# Altium schematic sheet Wifi, Cellular, MD and FRAM.SchDoc
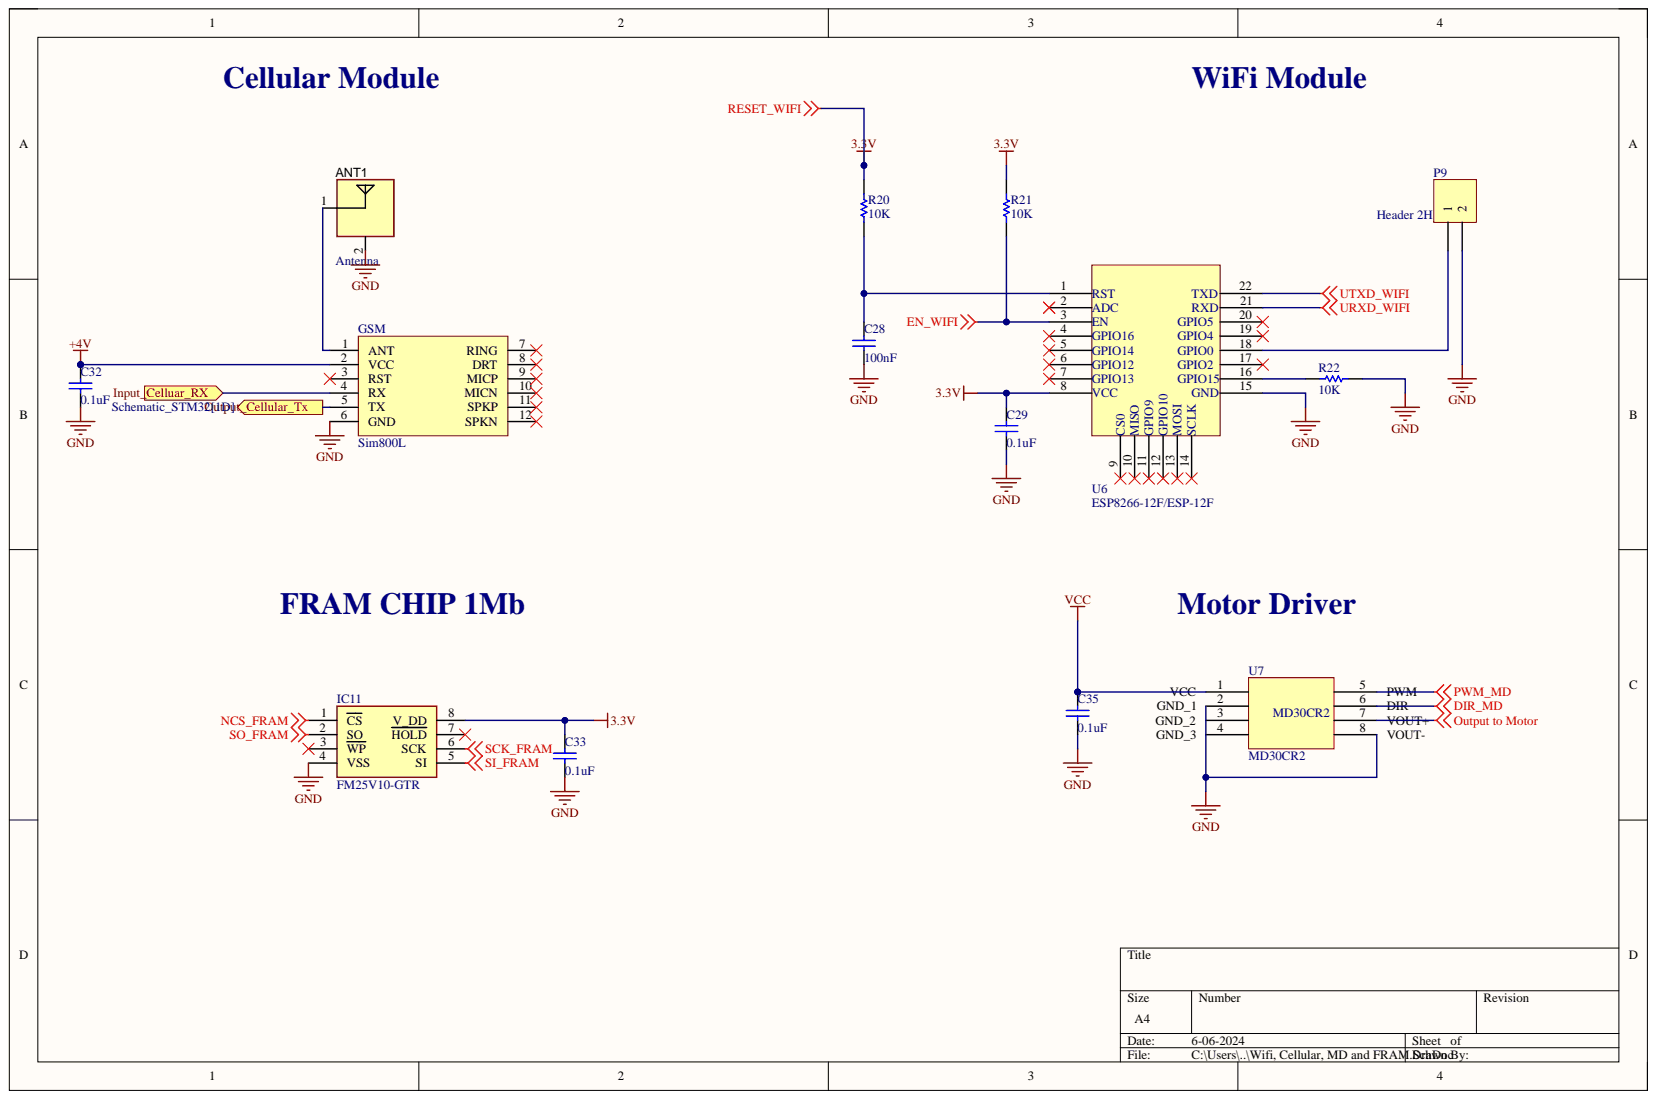
[diagram p0: Wifi, Cellular, MD and FRAM.SchDoc]

## Parts
ANT1  value Antenna  [ANT_IFA_2P4G]  (no BOM)
C28  value 100nF  [RAD-0.3]  (hidden comment: Cap)
C29  value 0.1uF  [RAD-0.3]  (hidden comment: Cap)
C32  value 0.1uF  [RAD-0.3]  (hidden comment: Cap)
C33  value 0.1uF  [RAD-0.3]  (hidden comment: Cap)
C35  value 0.1uF  [RAD-0.3]  (hidden comment: Cap)
GSM  Sim800L  [Sim800L]
IC11  FM25V10-GTR  [SOIC127P602X173-8N]
P9  Header 2H  [HDR1X2H]
R20  value 10K  [AXIAL-0.3]  (hidden comment: Res1)
R21  value 10K  [AXIAL-0.3]  (hidden comment: Res1)
R22  value 10K  [AXIAL-0.3]  (hidden comment: Res1)
U6  ESP8266-12F/ESP-12F
U7  MD30CR2

## Ports
Input_Celluar_RX, Output_Cellular_Tx

## Nets
+4V: C32.2 GSM.2(VCC)
EN_WIFI: R21.2
GND: ANT1.2 C28.1 C29.1 C32.1 C33.1 C35.1 GSM.6(GND) IC11.4(VSS) P9.2 R22.2
NCS_FRAM: IC11.1(CS)
RESET_WIFI: C29.2 C33.2 IC11.8(V_DD) R20.1 R21.1
SCK_FRAM: IC11.6(SCK)
SI_FRAM: IC11.5(SI)
SO_FRAM: IC11.2(SO)
VCC: C35.2
Input_Celluar_RX: GSM.4(RX) ; port Input_Celluar_RX
Output_Cellular_Tx: GSM.5(TX) ; port Output_Cellular_Tx
NetANT1_1: ANT1.1 GSM.1(ANT)
NetC28_2: C28.2 R20.2
DIR_MD:
Output to Motor:
PWM_MD:
URXD_WIFI:
UTXD_WIFI:

Not connected: GSM 3(RST) 7(RING) 8(DRT) 9(MICP) 10(MICN) 11(SPKP) 12(SPKN); IC11 3(WP) 7(HOLD); P9 1; R22 1; U6 1(RST) 2(ADC) 3(EN) 4(GPIO16) 5(GPIO14) 6(GPIO12) 7(GPIO13) 8(VCC) 9(CS0) 10(MISO) 11(GPIO9) 12(GPIO10) 13(MOSI) 14(SCLK) 15(GND) 16(GPIO15) 17(GPIO2) 18(GPIO0) 19(GPIO4) 20(GPIO5) 21(RXD) 22(TXD); U7 1 2 3 4 5 6 7 8

## Library data of the parts
ANT1 = TESTPOINT_SMD: TEST POINT; Library Name: antenna.SchLib; MANF: MANF; MANF_P#: MANF_P#
C28 C29 C32 C33 C35 = Cap: Capacitor; Component Kind: Standard; LatestRevisionDate: 17-Jul-2002; LatestRevisionNote: Re-released for DXP Platform.; Library Name: Miscellaneous Devices.IntLib; PackageDescription: Radial Cap, Thru-Hole; 2 Leads; 0.3 in Pin Spacing; PackageReference: RAD-0.3; Published: 8-Jun-2000; Signal Integrity: Cap; Simulation: CAP; Publisher: Altium Limited
GSM = Sim800L: GSM SIM800L; Component Kind: Standard; Library Name: Sim800L_Module.SchLib
IC11 = FM25V10-GTR: Integrated Circuit; Manufacturer_Name: Infineon; Arrow Part Number: FM25V10-GTR; Arrow Price/Stock: https://www.arrow.com/en/products/fm25v10-gtr/cypress-semiconductor; Component Kind: Standard; Datasheet Link: https://www.cypress.com/file/41691/download; Height: 1.727mm; Manufacturer_Part_Number: FM25V10-GTR; Mouser Part Number: 877-FM25V10-GTR; Mouser Price/Stock: https://www.mouser.co.uk/ProductDetail/Infineon-Technologies/FM25V10-GTR?qs=pA5MXup5wxEkqZoPzJHFgA%3D%3D
P9 = Header 2H: Header, 2-Pin, Right Angle; Component Kind: Standard; LatestRevisionDate: 17-Jul-2002; LatestRevisionNote: Re-released for DXP Platform.; Library Name: Miscellaneous Connectors.IntLib; Signal Integrity: Connector; Publisher: Altium Limited
R20-R22 = Res1: Resistor; Component Kind: Standard; LatestRevisionDate: 17-Jul-2002; LatestRevisionNote: Re-released for DXP Platform.; Library Name: Miscellaneous Devices.IntLib; PackageDescription: Axial Device, Thru-Hole; 2 Leads; 0.3 in Pin Spacing; PackageReference: AXIAL-0.3; Published: 8-Jun-2000; Signal Integrity: Res; Simulation: RESISTOR; Publisher: Altium Limited
U6 = ESP-12F: Integrated Circuit; MODEL NO: ESP8266 ESP-12F WiFi Module; Component Kind: Standard; Library Name: WIFI.SchLib; Module's dimensions: 24mm x 16mm x 3.4mm (0.95" x 0.63" x 0.13")
U7 = MD30CR2: Motor Driver; Component Kind: Standard; Datasheet: https://robu.in/wp-content/uploads/2014/12/MD30C-Users-Manual-Google-Docs.pdf; Library Name: MD30CR2.SchLib
